# Altium schematic sheet Parrot 1.1.2 - Top Sheet.SchDoc
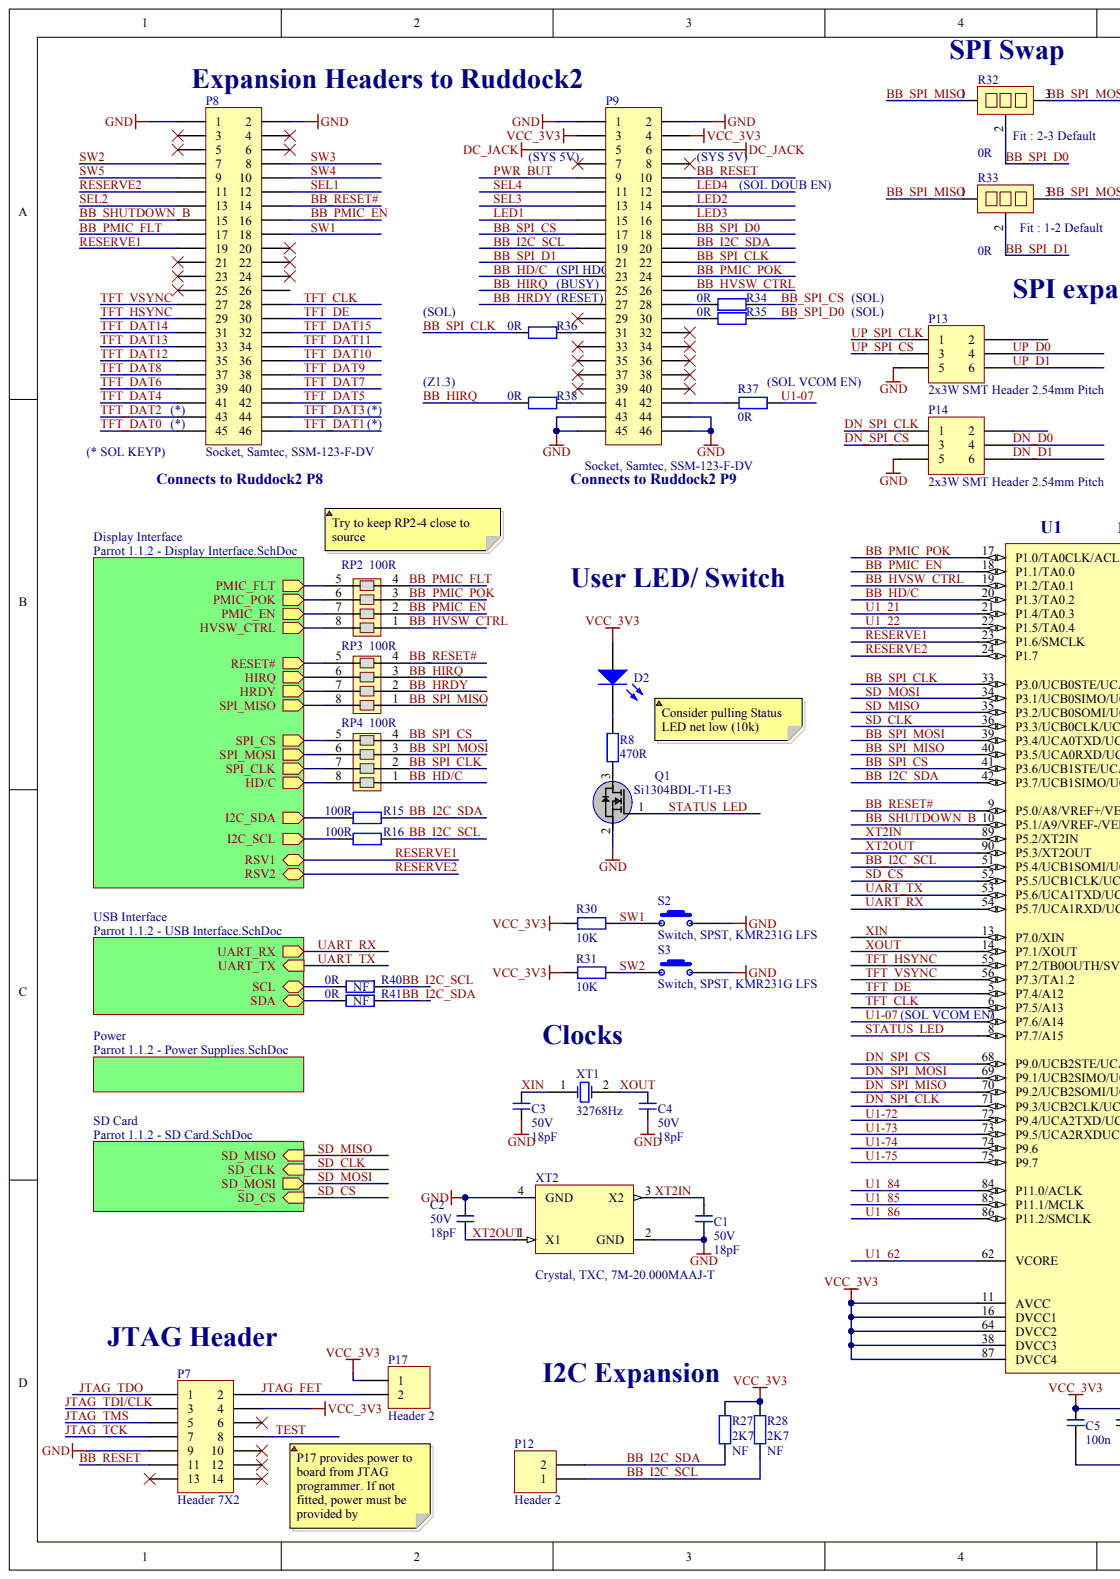
[diagram p0: Parrot 1.1.2 - Top Sheet.SchDoc, left]
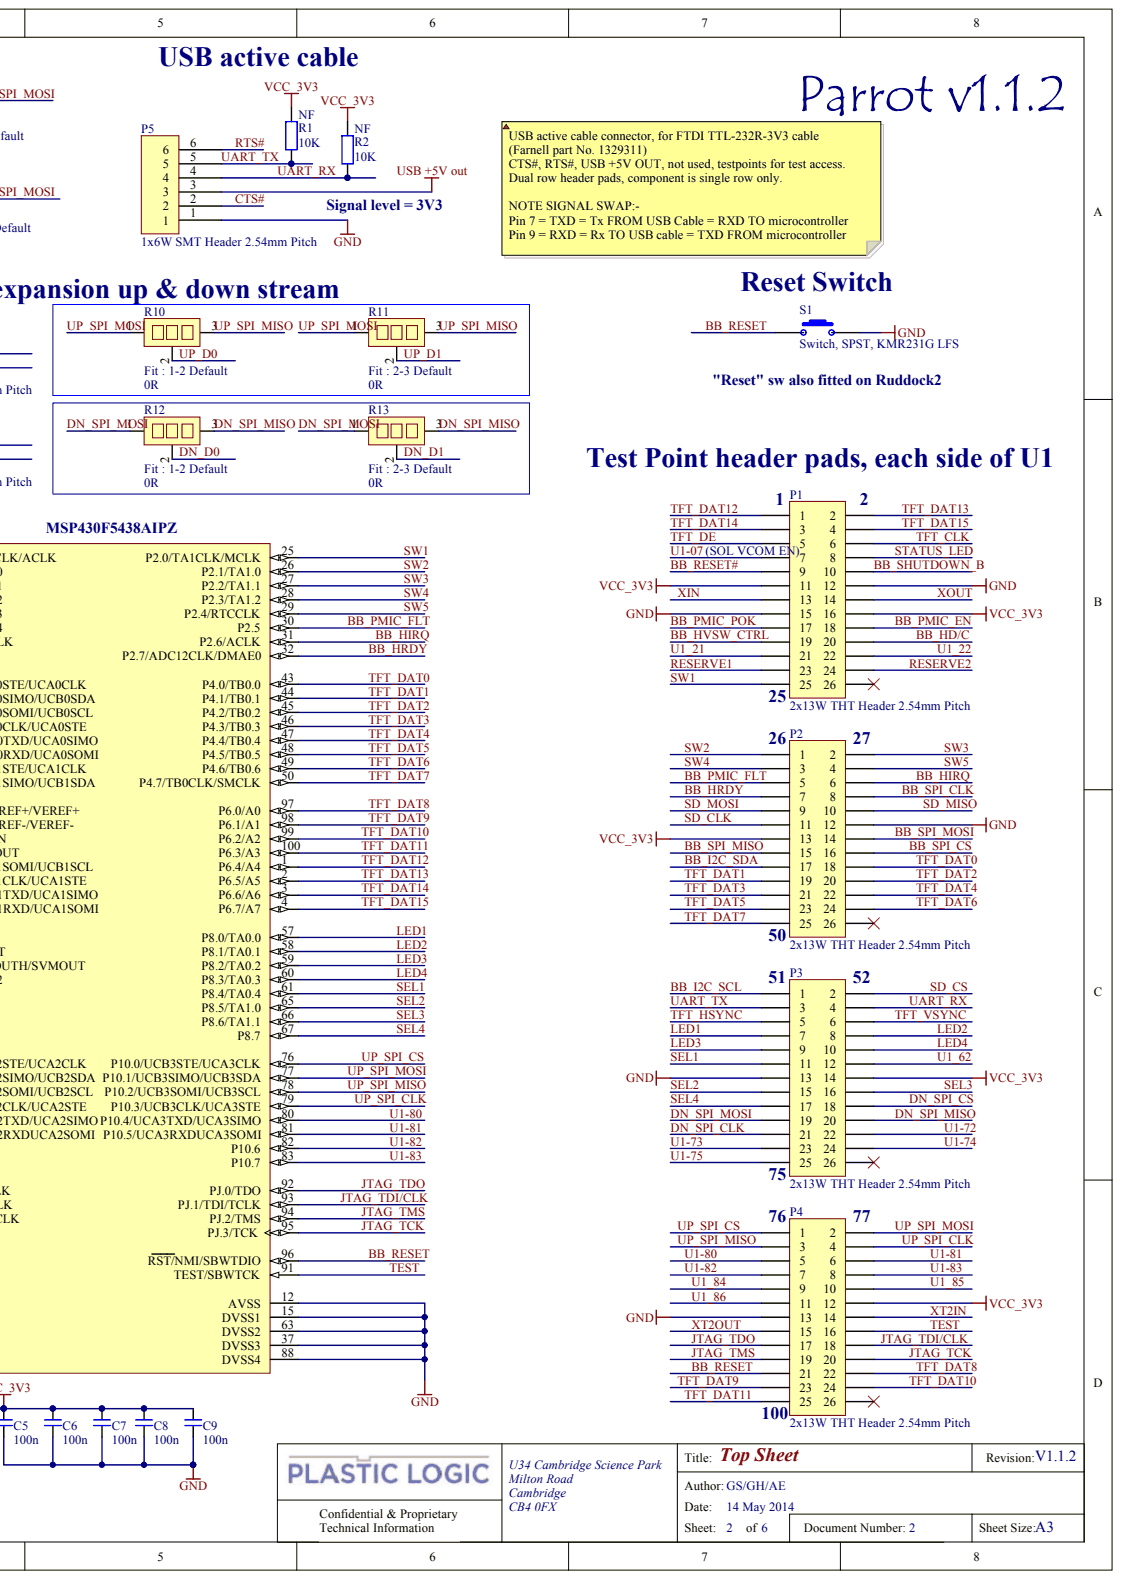
[diagram p1: Parrot 1.1.2 - Top Sheet.SchDoc, right]

## Parts
C1  Voltage: 50V  (hidden comment: 18pF)
C2  Voltage: 50V  (hidden comment: 18pF)
C3  Voltage: 50V  (hidden comment: 18pF)
C4  Voltage: 50V  (hidden comment: 18pF)
C5  100n
C6  100n
C7  100n
C8  100n
C9  100n
D2  LED2  (value not drawn)
P1  2x13W THT Header 2.54mm Pitch
P2  2x13W THT Header 2.54mm Pitch
P3  2x13W THT Header 2.54mm Pitch
P4  2x13W THT Header 2.54mm Pitch
P5  1x6W SMT Header 2.54mm Pitch
P7  Header 7X2
P8  Socket, Samtec, SSM-123-F-DV  ManufacturerPN: SSM-123-F-DV
P9  Socket, Samtec, SSM-123-F-DV  ManufacturerPN: SSM-123-F-DV
P12  Header 2
P13  2x3W SMT Header 2.54mm Pitch
P14  2x3W SMT Header 2.54mm Pitch
P17  Header 2
Q1  Si1304BDL-T1-E3
R1  Fitted: NF  (hidden comment: 10K)
R2  Fitted: NF  (hidden comment: 10K)
R8  470R
R10  Fit : 1-2 Default  value 0R
R11  Fit : 2-3 Default  value 0R
R12  Fit : 1-2 Default  value 0R
R13  Fit : 2-3 Default  value 0R
R15  100R
R16  100R
R27  Fitted: NF  (hidden comment: 2K7)
R28  Fitted: NF  (hidden comment: 2K7)
R30  10K
R31  10K
R32  Fit : 2-3 Default  value 0R
R33  Fit : 1-2 Default  value 0R
R34  0R
R35  0R
R36  0R
R37  0R
R38  0R
R40  Fitted: NF  (hidden comment: 0R)
R41  Fitted: NF  (hidden comment: 0R)
RP2  100R
RP3  100R
RP4  100R
S1  Switch, SPST, KMR231G LFS
S2  Switch, SPST, KMR231G LFS
S3  Switch, SPST, KMR231G LFS
U1  MSP430F5438AIPZ
XT1  32768Hz
XT2  Crystal, TXC, 7M-20.000MAAJ-T  ManufacturerPN: 7M-20.000MAAJ-T

## Nets
DC_JACK: P9.5 P9.6
GND: C1.1 C2.1 C3.2 C4.1 C5.2 C6.2 C7.2 C8.2 C9.2 P1.12 P1.15 P2.12 P3.13 P4.13 P5.1 P7.9 P8.1 P8.2 P9.1 P9.2 P9.43 P9.44 P9.45 P9.46 P13.5 P14.5 Q1.2 S1.2 S1.5 S2.2 S2.5 S3.2 S3.5 U1.12(AVSS) U1.15(DVSS1) U1.37(DVSS3) U1.63(DVSS2) U1.88(DVSS4) XT2.2(GND) XT2.4(GND)
USB +5V OUT: P5.3
VCC_3V3: C5.1 C6.1 C7.1 C8.1 C9.1 D2.1 P1.11 P1.16 P2.13 P3.14 P4.12 P7.4 P9.3 P9.4 P17.1 R1.1 R2.1 R27.1 R28.1 R30.1 R31.1 U1.11(AVCC) U1.16(DVCC1) U1.38(DVCC3) U1.64(DVCC2) U1.87(DVCC4)
BB_HD/C: P1.20 P9.23 RP4.1 U1.20(P1.3/TA0.2)
BB_HIRQ: P2.6 P9.25 R38.2 RP3.3 U1.31(P2.6/ACLK)
BB_HRDY: P2.7 P9.27 RP3.2 U1.32(P2.7/ADC12CLK/DMAE0)
BB_HVSW_CTRL: P1.19 P9.26 RP2.1 U1.19(P1.2/TA0.1)
BB_I2C_SCL: P3.1 P9.19 P12.1 R16.2 R28.2 R40.1 U1.51(P5.4/UCB1SOMI/UCB1SCL)
BB_I2C_SDA: P2.17 P9.20 P12.2 R15.2 R27.2 R41.1 U1.42(P3.7/UCB1SIMO/UCB1SDA)
BB_PMIC_EN: P1.18 P8.16 RP2.2 U1.18(P1.1/TA0.0)
BB_PMIC_FLT: P2.5 P8.17 RP2.4 U1.30(P2.5)
BB_PMIC_POK: P1.17 P9.24 RP2.3 U1.17(P1.0/TA0CLK/ACLK)
BB_RESET: P4.21 P7.11 P9.10 S1.1 U1.96(RST/NMI/SBWTDIO)
BB_RESET#: P1.9 P8.14 RP3.4 U1.9(P5.0/A8/VREF+/VEREF+)
BB_SHUTDOWN_B: P1.10 P8.15 U1.10(P5.1/A9/VREF-/VEREF-)
BB_SPI_CLK: P2.8 P9.22 R36.2 RP4.2 U1.33(P3.0/UCB0STE/UCA0CLK)
BB_SPI_CS: P2.16 P9.17 R34.2 RP4.4 U1.41(P3.6/UCB1STE/UCA1CLK)
BB_SPI_D0: P9.18 R32.2 R35.1
BB_SPI_D1: P9.21 R33.2
BB_SPI_MISO: P2.15 R32.1 R33.1 RP3.1 U1.40(P3.5/UCA0RXD/UCA0SOMI)
BB_SPI_MOSI: P2.14 R32.3 R33.3 RP4.3 U1.39(P3.4/UCA0TXD/UCA0SIMO)
CTS#: P5.2
DN_D0: P14.4 R12.2
DN_D1: P14.6 R13.2
DN_SPI_CLK: P3.21 P14.1 U1.71(P9.3/UCB2CLK/UCA2STE)
DN_SPI_CS: P3.18 P14.3 U1.68(P9.0/UCB2STE/UCA2CLK)
DN_SPI_MISO: P3.20 R12.3 R13.3 U1.70(P9.2/UCB2SOMI/UCB2SCL)
DN_SPI_MOSI: P3.19 R12.1 R13.1 U1.69(P9.1/UCB2SIMO/UCB2SDA)
JTAG_FET: P7.2 P17.2
JTAG_TCK: P4.20 P7.7 U1.95(PJ.3/TCK)
JTAG_TDI/CLK: P4.18 P7.3 U1.93(PJ.1/TDI/TCLK)
JTAG_TDO: P4.17 P7.1 U1.92(PJ.0/TDO)
JTAG_TMS: P4.19 P7.5 U1.94(PJ.2/TMS)
LED1: P3.7 P9.15 U1.57(P8.0/TA0.0)
LED2: P3.8 P9.14 U1.58(P8.1/TA0.1)
LED3: P3.9 P9.16 U1.59(P8.2/TA0.2)
LED4: P3.10 P9.12 U1.60(P8.3/TA0.3)
PWR_BUT: P9.9
RESERVE1: P1.23 P8.19 U1.23(P1.6/SMCLK)
RESERVE2: P1.24 P8.11 U1.24(P1.7)
RTS#: P5.6
SD_CLK: P2.11 U1.36(P3.3/UCB0CLK/UCA0STE)
SD_CS: P3.2 U1.52(P5.5/UCB1CLK/UCA1STE)
SD_MISO: P2.10 U1.35(P3.2/UCB0SOMI/UCB0SCL)
SD_MOSI: P2.9 U1.34(P3.1/UCB0SIMO/UCB0SDA)
SEL1: P3.11 P8.12 U1.61(P8.4/TA0.4)
SEL2: P3.15 P8.13 U1.65(P8.5/TA1.0)
SEL3: P3.16 P9.13 U1.66(P8.6/TA1.1)
SEL4: P3.17 P9.11 U1.67(P8.7)
STATUS_LED: P1.8 Q1.1 U1.8(P7.7/A15)
SW1: P1.25 P8.18 R30.2 S2.1 U1.25(P2.0/TA1CLK/MCLK)
SW2: P2.1 P8.7 R31.2 S3.1 U1.26(P2.1/TA1.0)
SW3: P2.2 P8.8 U1.27(P2.2/TA1.1)
SW4: P2.3 P8.10 U1.28(P2.3/TA1.2)
SW5: P2.4 P8.9 U1.29(P2.4/RTCCLK)
TEST: P4.16 P7.8 U1.91(TEST/SBWTCK)
TFT_CLK: P1.6 P8.28 U1.6(P7.5/A13)
TFT_DAT0: P2.18 P8.45 U1.43(P4.0/TB0.0)
TFT_DAT1: P2.19 P8.46 U1.44(P4.1/TB0.1)
TFT_DAT2: P2.20 P8.43 U1.45(P4.2/TB0.2)
TFT_DAT3: P2.21 P8.44 U1.46(P4.3/TB0.3)
TFT_DAT4: P2.22 P8.41 U1.47(P4.4/TB0.4)
TFT_DAT5: P2.23 P8.42 U1.48(P4.5/TB0.5)
TFT_DAT6: P2.24 P8.39 U1.49(P4.6/TB0.6)
TFT_DAT7: P2.25 P8.40 U1.50(P4.7/TB0CLK/SMCLK)
TFT_DAT8: P4.22 P8.37 U1.97(P6.0/A0)
TFT_DAT9: P4.23 P8.38 U1.98(P6.1/A1)
TFT_DAT10: P4.24 P8.36 U1.99(P6.2/A2)
TFT_DAT11: P4.25 P8.34 U1.100(P6.3/A3)
TFT_DAT12: P1.1 P8.35 U1.1(P6.4/A4)
TFT_DAT13: P1.2 P8.33 U1.2(P6.5/A5)
TFT_DAT14: P1.3 P8.31 U1.3(P6.6/A6)
TFT_DAT15: P1.4 P8.32 U1.4(P6.7/A7)
TFT_DE: P1.5 P8.30 U1.5(P7.4/A12)
TFT_HSYNC: P3.5 P8.29 U1.55(P7.2/TB0OUTH/SVMOUT)
TFT_VSYNC: P3.6 P8.27 U1.56(P7.3/TA1.2)
U1-07: P1.7 R37.1 U1.7(P7.6/A14)
U1-72: P3.22 U1.72(P9.4/UCA2TXD/UCA2SIMO)
U1-73: P3.23 U1.73(P9.5/UCA2RXDUCA2SOMI)
U1-74: P3.24 U1.74(P9.6)
U1-75: P3.25 U1.75(P9.7)
U1-80: P4.5 U1.80(P10.4/UCA3TXD/UCA3SIMO)
U1-81: P4.6 U1.81(P10.5/UCA3RXDUCA3SOMI)
U1-82: P4.7 U1.82(P10.6)
U1-83: P4.8 U1.83(P10.7)
U1_21: P1.21 U1.21(P1.4/TA0.3)
U1_22: P1.22 U1.22(P1.5/TA0.4)
U1_62: P3.12 U1.62(VCORE)
U1_84: P4.9 U1.84(P11.0/ACLK)
U1_85: P4.10 U1.85(P11.1/MCLK)
U1_86: P4.11 U1.86(P11.2/SMCLK)
UART_RX: P3.4 P5.4 R2.2 U1.54(P5.7/UCA1RXD/UCA1SOMI)
UART_TX: P3.3 P5.5 R1.2 U1.53(P5.6/UCA1TXD/UCA1SIMO)
UP_D0: P13.4 R10.2
UP_D1: P13.6 R11.2
UP_SPI_CLK: P4.4 P13.1 U1.79(P10.3/UCB3CLK/UCA3STE)
UP_SPI_CS: P4.1 P13.3 U1.76(P10.0/UCB3STE/UCA3CLK)
UP_SPI_MISO: P4.3 R10.3 R11.3 U1.78(P10.2/UCB3SOMI/UCB3SCL)
UP_SPI_MOSI: P4.2 R10.1 R11.1 U1.77(P10.1/UCB3SIMO/UCB3SDA)
XIN: C3.1 P1.13 U1.13(P7.0/XIN) XT1.1
XOUT: C4.2 P1.14 U1.14(P7.1/XOUT) XT1.2
XT2IN: C1.2 P4.14 U1.89(P5.2/XT2IN) XT2.3(X2)
XT2OUT: C2.2 P4.15 U1.90(P5.3/XT2OUT) XT2.1(X1)
NetD2_2: D2.2 R8.2
NetP1_26: P1.26
NetP2_26: P2.26
NetP3_26: P3.26
NetP4_26: P4.26
NetP7_6: P7.6
NetP7_10: P7.10
NetP7_12: P7.12
NetP7_13: P7.13
NetP7_14: P7.14
NetP8_3: P8.3
NetP8_4: P8.4
NetP8_5: P8.5
NetP8_6: P8.6
NetP8_20: P8.20
NetP8_21: P8.21
NetP8_22: P8.22
NetP8_23: P8.23
NetP8_24: P8.24
NetP8_25: P8.25
NetP8_26: P8.26
NetP9_7: P9.7
NetP9_8: P9.8
NetP9_28: P9.28 R34.1
NetP9_29: P9.29
NetP9_30: P9.30 R35.2
NetP9_31: P9.31 R36.1
NetP9_32: P9.32
NetP9_33: P9.33
NetP9_34: P9.34
NetP9_35: P9.35
NetP9_36: P9.36
NetP9_37: P9.37
NetP9_38: P9.38
NetP9_39: P9.39
NetP9_40: P9.40
NetP9_41: P9.41 R38.1
NetP9_42: P9.42 R37.2
NetP13_2: P13.2
NetP14_2: P14.2
NetQ1_3: Q1.3 R8.1
NetR15_1: R15.1
NetR16_1: R16.1
NetR40_2: R40.2
NetR41_2: R41.2
NetRP2_5: RP2.5
NetRP2_6: RP2.6
NetRP2_7: RP2.7
NetRP2_8: RP2.8
NetRP3_5: RP3.5
NetRP3_6: RP3.6
NetRP3_7: RP3.7
NetRP3_8: RP3.8
NetRP4_5: RP4.5
NetRP4_6: RP4.6
NetRP4_7: RP4.7
NetRP4_8: RP4.8

## Library data of the parts
C1-C4 = (no symbol name): Manufacturer: TDK; ManufacturerPN: C1608COG1H180J080AA; Tolerance: 1%; Material: NP0; Fitted: I; Order Code: 1907287; Value: 18pF; Minimum Order: 100; SupplierPN: 1907287; Supplier: Farnell; Supplier Cost: 0.011
C5-C9 = (no symbol name): Manufacturer: AVX; ManufacturerPN: 06033C104KAZ2A; Tolerance: 10%; Fitted: I; Material: X7R; Voltage: 25V; Order Code: 1833840; Value: 100n; SupplierPN: 1833840; Supplier: Farnell; Minimum Order: 5; Supplier Cost: 0.124
D2 = (no symbol name): Manufacturer: KINGBRIGHT; ManufacturerPN: KP-1608MGC; Value: LED GREEN; Fitted: I; Order Code: 8529825; SupplierPN: 8529825; Supplier: Farnell; Minimum Order: 10; Supplier Cost: 0.36
P1-P4 = (no symbol name): Manufacturer: Samtec; ManufacturerPN: TSW-113-14-F-D; Fitted: NF; Value: HEADER, 2.54MM, THT VERT, 26WAY; Order Code: 2027007; Supplier Cost: 2.14; Minimum Order: 5; SupplierPN: 2027007; Supplier: Farnell
P5 = (no symbol name): Manufacturer: Samtec; ManufacturerPN: TSM-102-01-L-SV; Fitted: I; Value: HEADER, 2.54MM, SMT, 1X6WAY; Order Code: 1885916; Minimum Order: 1; SupplierPN: 1885916; Supplier: Farnell; Supplier Cost: 0.81
P7 = (no symbol name): Manufacturer: TE CONNECTIVITY / AMP; ManufacturerPN: 826942-7; Fitted: I; Value: HEADER, STRAIGHT, 7x2WAY; Order Code: 1248163; Minimum Order: 10; SupplierPN: 1248163; Supplier: Farnell; Supplier Cost: 0.68
P8 P9 = (no symbol name): Fitted: I; Value: SOCKET, 2.54MM, SMT, 2X23WAY; Order Code: SSM-123-L-DV-A; Manufacturer: SAMTEC; Minimum Order: 1; SupplierPN: SSM-123-L-DV-A; Supplier: Toby
P12 P17 = (no symbol name): Manufacturer: TE CONNECTIVITY / AMP; ManufacturerPN: 826926-2; Fitted: NF; Value: HEADER, STRAIGHT, 2WAY; Order Code: 1248140; Minimum Order: 10; SupplierPN: 1248140; Supplier: Farnell; Supplier Cost: 0.11
P13 P14 = (no symbol name): Manufacturer: Samtec; ManufacturerPN: TSM-103-01-F-DV; Fitted: NF; Value: HEADER, 2.54MM, SMT, 2X3WAY; Order Code: 1803391; Minimum Order: 10; SupplierPN: 1803391; Supplier: Farnell; Supplier Cost: 0.95
Q1 = (no symbol name): Manufacturer: Vishay-Siliconix; Fitted: I; Order Code: 2101433; Value: Si1304BDL-T1-E3; ManufacturerPN: Si1304BDL-T1-E3; SupplierPN: 2101433; Supplier: Farnell; Minimum Order: 1; Supplier Cost: 0.44
R1 R2 = (no symbol name): Manufacturer: Bourns; ManufacturerPN: CR0603-FX-1002ELF; Tolerance: 1%; Order Code: 2008341; Value: 10K; SupplierPN: 2008341; Supplier: Farnell; Minimum Order: 50; Supplier Cost: 0.015
R8 = (no symbol name): Manufacturer: VISHAY DRALORIC; ManufacturerPN: CRCW0603470RFKEA; Tolerance: 1%; Fitted: I; Voltage: 75V; Order Code: 1469815; Value: 470R; SupplierPN: 1469815; Supplier: Farnell; Material: Thick Film; Minimum Order: 50; Supplier Cost: 0.011
R10 R11 = (no symbol name): Manufacturer: VISHAY DRALORIC; ManufacturerPN: CRCW06030000Z0EA; Value: 0R; Voltage: 75V; Order Code: 1469739; Material: Thick Film; Minimum Order: 50; SupplierPN: 1469739; Supplier: Farnell; Supplier Cost: 0.02
  R10: Fitted: Fit : 1-2 Default
  R11: Fitted: Fit : 2-3 Default
R12 R13 R33 R40 R41 = (no symbol name): Manufacturer: VISHAY DRALORIC; ManufacturerPN: CRCW06030000Z0EA; Voltage: 75V; Order Code: 1469739; Material: Thick Film; Minimum Order: 50; SupplierPN: 1469739; Supplier: Farnell; Supplier Cost: 0.02
  R12 R33: Fitted: Fit : 1-2 Default
  R13: Fitted: Fit : 2-3 Default
  R40 R41: Value: 0R
R15 R16 = (no symbol name): Manufacturer: WELWYN; ManufacturerPN: ASC0603-100RFT5; Tolerance: 1%; Power: 0.1W; Fitted: I; Voltage: 50V; Order Code: 2078901; Value: 100R; Material: Thick Film; Minimum Order: 100; SupplierPN: 2078901; Supplier: Farnell; Supplier Cost: 0.007
R27 R28 = (no symbol name): Manufacturer: VISHAY DRALORIC; ManufacturerPN: CRCW06032K70FKEA; Tolerance: 1%; Voltage: 75V; Order Code: 1469768; Value: 2K7; SupplierPN: 1469768; Supplier: Farnell; Material: Thick Film; Minimum Order: 50; Supplier Cost: 0.011
R30 R31 = (no symbol name): Manufacturer: WELWYN; ManufacturerPN: ASC0603-10KFT5; Tolerance: 1%; Fitted: I; Voltage: 50V; Order Code: 2078915; Value: 10K; SupplierPN: 2078915; Supplier: Farnell; Material: Thick Film; Minimum Order: 100; Supplier Cost: 0.007
R32 = (no symbol name): Manufacturer: VISHAY DRALORIC; ManufacturerPN: CRCW06030000Z0EA; Fitted: Fit : 1-2 Default; Value: 0R; Voltage: 75V; Order Code: 1469739; Material: Thick Film; Minimum Order: 50; SupplierPN: 1469739; Supplier: Farnell; Supplier Cost: 0.02
R34-R38 = (no symbol name): Manufacturer: VISHAY DRALORIC; ManufacturerPN: CRCW06030000Z0EA; Fitted: I; Voltage: 75V; Order Code: 1469739; Value: 0R; SupplierPN: 1469739; Supplier: Farnell; Material: Thick Film; Minimum Order: 50; Supplier Cost: 0.02
RP2-RP4 = (no symbol name): Manufacturer: Panasonic; ManufacturerPN: EXB28VR101JX; Fitted: I; Tolerance: 5%; Voltage: 50V; Order Code: 2060044; Value: 100R; SupplierPN: 2060044; Supplier: Farnell; Minimum Order: 1; Supplier Cost: 0.034
S1-S3 = (no symbol name): Manufacturer: C & K COMPONENTS; Fitted: I; Value: TACTILE SWITCH, SMD, 3N; Order Code: 1437636; ManufacturerPN: KMR231G LFS; Minimum Order: 1; SupplierPN: 1437636; Supplier: Farnell; Supplier Cost: 0.52
U1 = (no symbol name): Manufacturer: TI; Fitted: I; Order Code: 1903452; Supplier: Farnell; SupplierPN: 1903452; Value: MSP430F5438AIPZ; ManufacturerPN: MSP430F5438AIPZ; Minimum Order: 1; Supplier Cost: 7.96
XT1 = (no symbol name): Manufacturer: Citizen; ManufacturerPN: CM31532.768KDZF-UT; Value: 32.768Hz; Fitted: I; Order Code: 1838939; Supplier: Citizen; SupplierPN: 1838939; Minimum Order: 1; Supplier Cost: 2.38
XT2 = (no symbol name): Fitted: I; Value: XTAL, 20.000MHZ, 18PF; Order Code: 1842064; Manufacturer: TXC; Minimum Order: 1; SupplierPN: 1842064; Supplier: Farnell; Supplier Cost: 0.83
